# Altium schematic sheet Sheet1.SchDoc
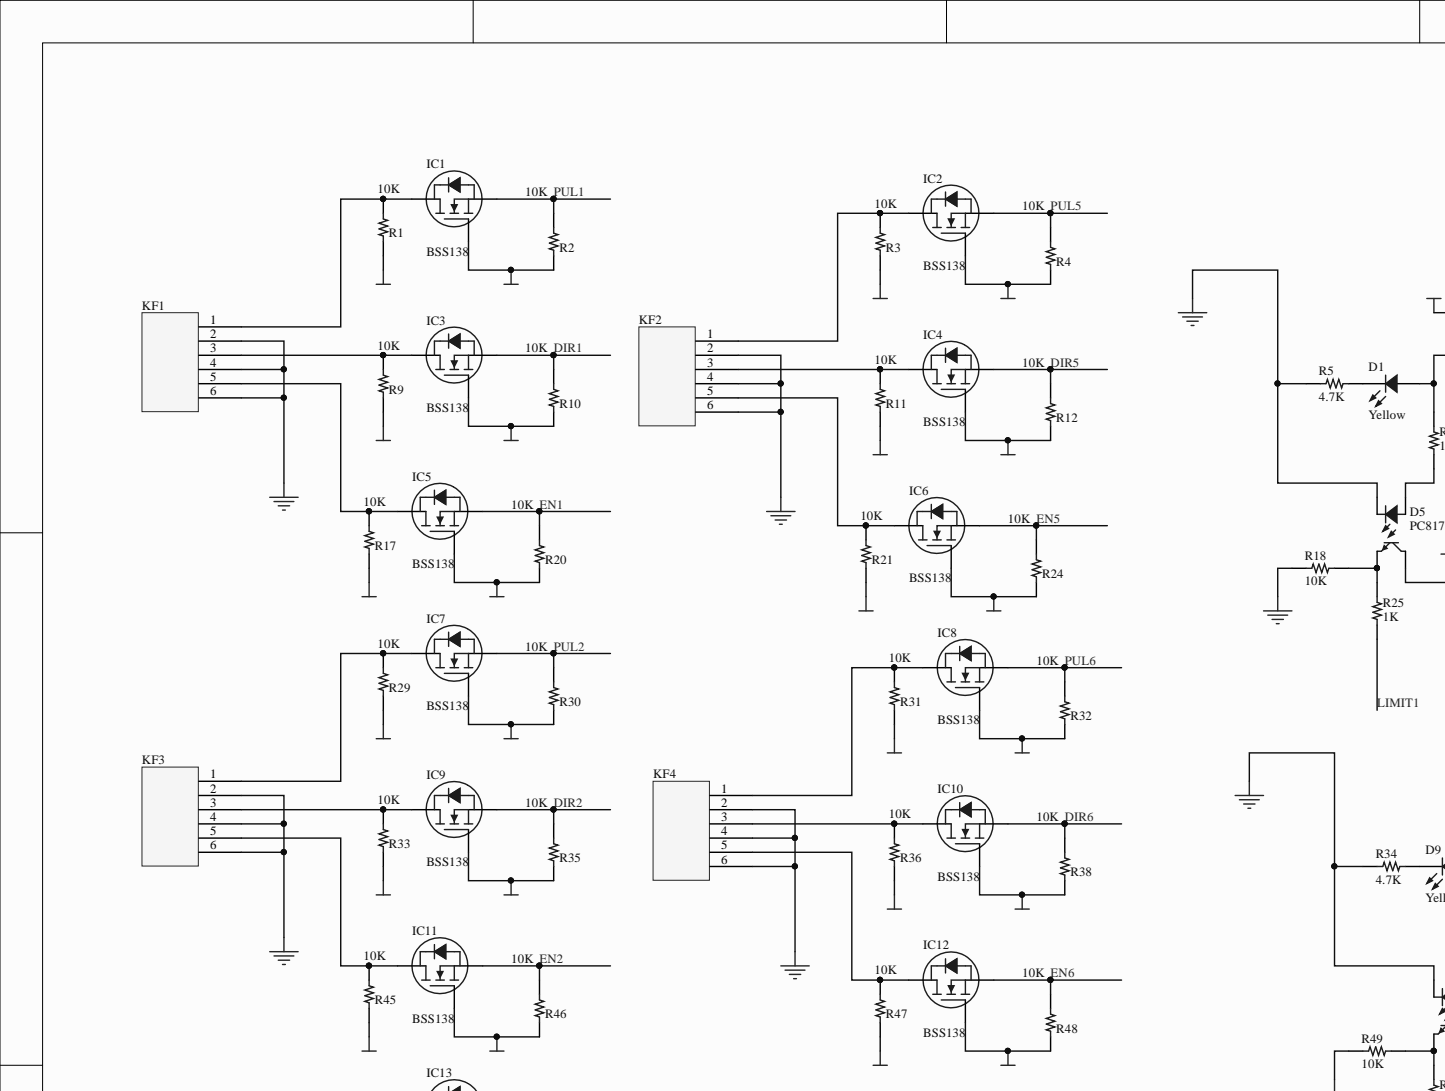
[diagram p0: Sheet1.SchDoc, top left]
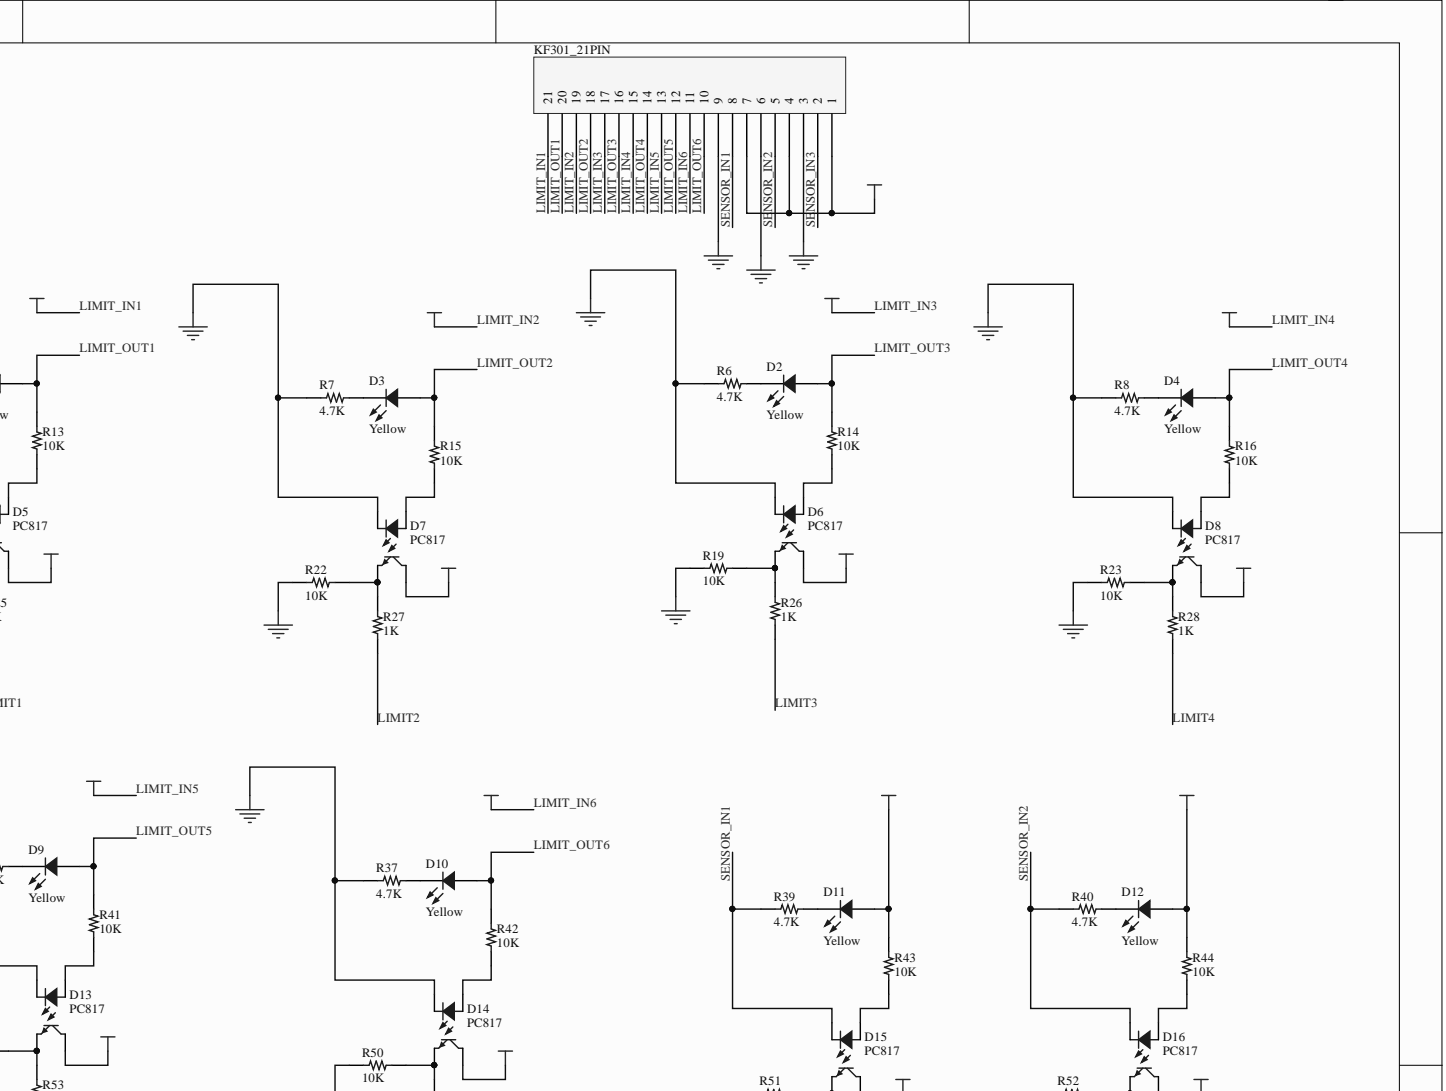
[diagram p1: Sheet1.SchDoc, top right]
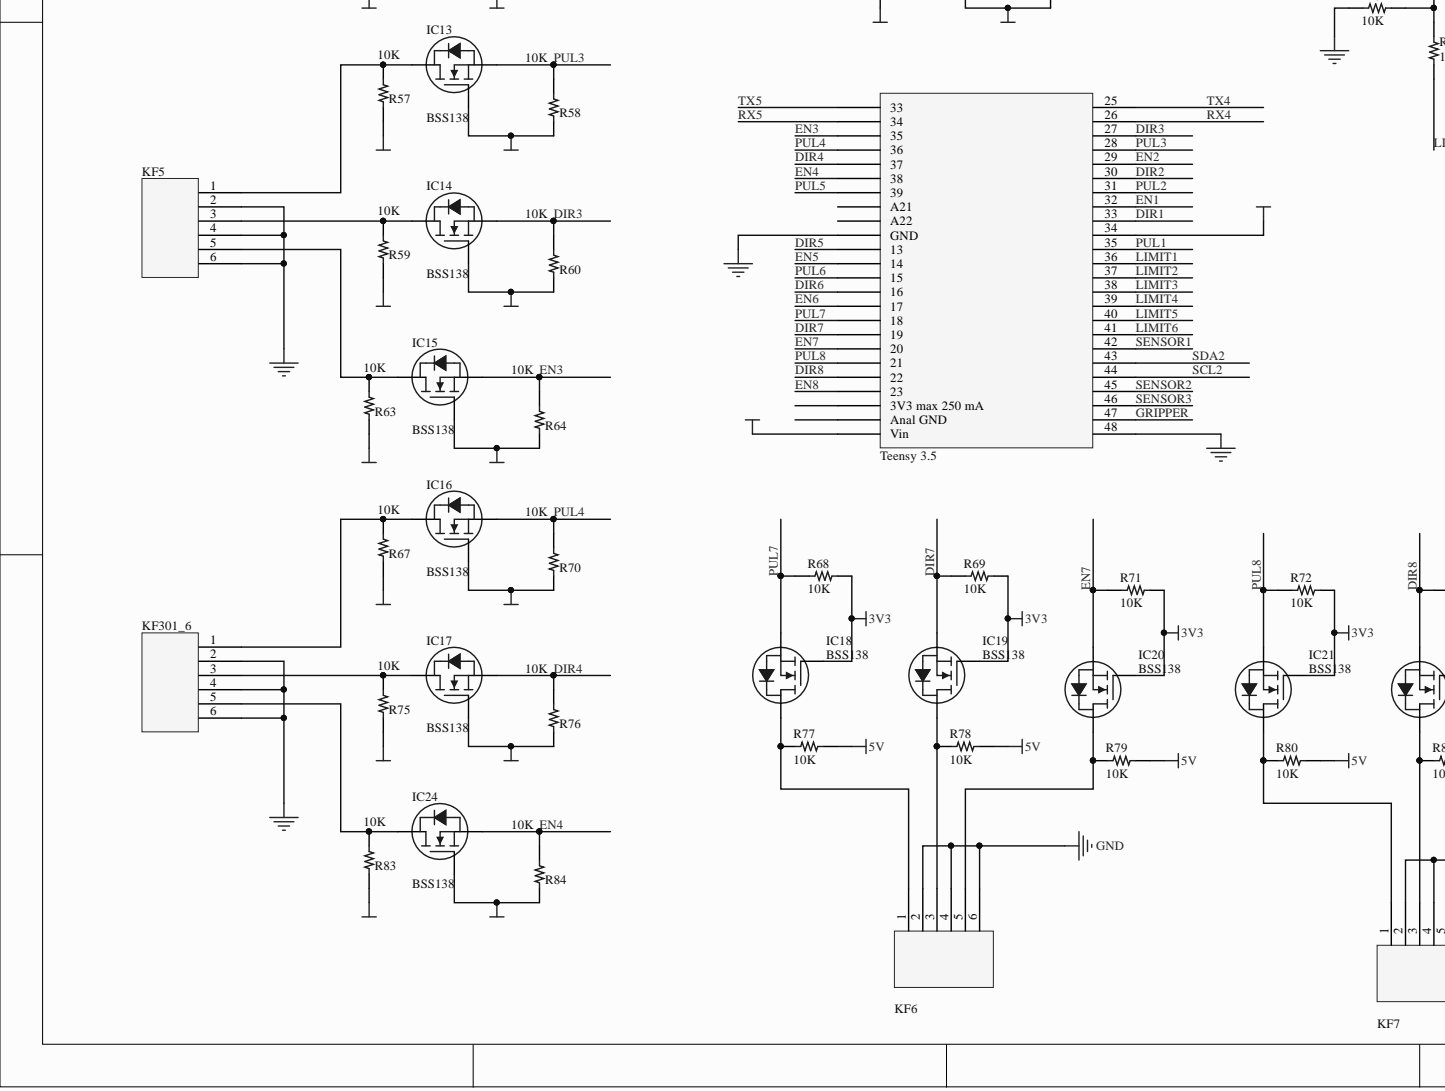
[diagram p2: Sheet1.SchDoc, bottom left]
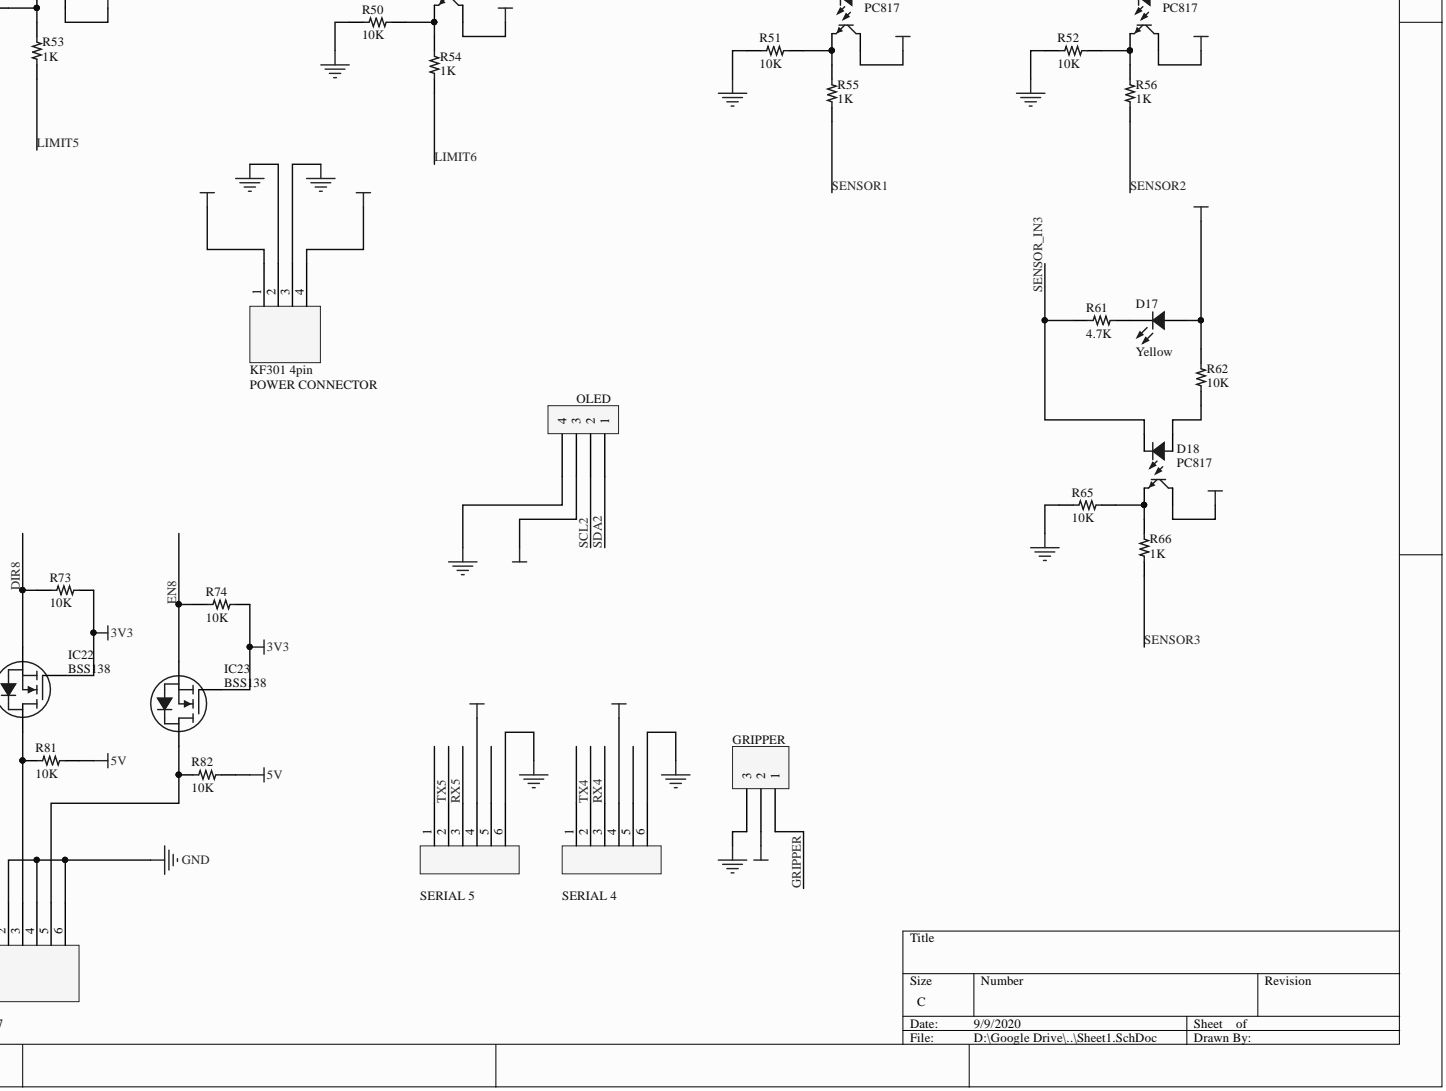
[diagram p3: Sheet1.SchDoc, bottom right]

## Parts
*  OLED  [4pin]
*  SERIAL 5  [header_6pin]
*?  SERIAL 4  [header_6pin]
D1  Yellow  [LED 0603_1608 YELLOW]
D2  Yellow  [LED 0603_1608 YELLOW]
D3  Yellow  [LED 0603_1608 YELLOW]
D4  Yellow  [LED 0603_1608 YELLOW]
D5  PC817  [LITEON LTV-817]
D6  PC817  [LITEON LTV-817]
D7  PC817  [LITEON LTV-817]
D8  PC817  [LITEON LTV-817]
D9  Yellow  [LED 0603_1608 YELLOW]
D10  Yellow  [LED 0603_1608 YELLOW]
D11  Yellow  [LED 0603_1608 YELLOW]
D12  Yellow  [LED 0603_1608 YELLOW]
D13  PC817  [LITEON LTV-817]
D14  PC817  [LITEON LTV-817]
D15  PC817  [LITEON LTV-817]
D16  PC817  [LITEON LTV-817]
D17  Yellow  [LED 0603_1608 YELLOW]
D18  PC817  [LITEON LTV-817]
GRIPPER  Component_3  [KF301_3PIN]  (value not drawn)
IC1  BSS138  [DIODES SOT-23-3]
IC2  BSS138  [DIODES SOT-23-3]
IC3  BSS138  [DIODES SOT-23-3]
IC4  BSS138  [DIODES SOT-23-3]
IC5  BSS138  [DIODES SOT-23-3]
IC6  BSS138  [DIODES SOT-23-3]
IC7  BSS138  [DIODES SOT-23-3]
IC8  BSS138  [DIODES SOT-23-3]
IC9  BSS138  [DIODES SOT-23-3]
IC10  BSS138  [DIODES SOT-23-3]
IC11  BSS138  [DIODES SOT-23-3]
IC12  BSS138  [DIODES SOT-23-3]
IC13  BSS138  [DIODES SOT-23-3]
IC14  BSS138  [DIODES SOT-23-3]
IC15  BSS138  [DIODES SOT-23-3]
IC16  BSS138  [DIODES SOT-23-3]
IC17  BSS138  [DIODES SOT-23-3]
IC18  BSS138  [DIODES SOT-23-3]
IC19  BSS138  [DIODES SOT-23-3]
IC20  BSS138  [DIODES SOT-23-3]
IC21  BSS138  [DIODES SOT-23-3]
IC22  BSS138  [DIODES SOT-23-3]
IC23  BSS138  [DIODES SOT-23-3]
IC24  BSS138  [DIODES SOT-23-3]
KF1  component_2  [KF301_6pin_pcb]  (value not drawn)
KF2  component_2  [KF301_6pin_pcb]  (value not drawn)
KF3  component_2  [KF301_6pin_pcb]  (value not drawn)
KF4  component_2  [KF301_6pin_pcb]  (value not drawn)
KF5  component_2  [KF301_6pin_pcb]  (value not drawn)
KF6  component_2  [KF301_6pin_pcb]  (value not drawn)
KF7  component_2  [KF301_6pin_pcb]  (value not drawn)
KF301_4pin  POWER CONNECTOR  [KF301_4pin]
KF301_6  component_2  [KF301_6pin_pcb]  (value not drawn)
KF301_21PIN  Component_1  [PCBComponent_1]  (value not drawn)
R1  10K  [RES 0603_1608]
R2  10K  [RES 0603_1608]
R3  10K  [RES 0603_1608]
R4  10K  [RES 0603_1608]
R5  4.7K  [RES 0603_1608]
R6  4.7K  [RES 0603_1608]
R7  4.7K  [RES 0603_1608]
R8  4.7K  [RES 0603_1608]
R9  10K  [RES 0603_1608]
R10  10K  [RES 0603_1608]
R11  10K  [RES 0603_1608]
R12  10K  [RES 0603_1608]
R13  10K  [RES 0603_1608]
R14  10K  [RES 0603_1608]
R15  10K  [RES 0603_1608]
R16  10K  [RES 0603_1608]
R17  10K  [RES 0603_1608]
R18  10K  [RES 0603_1608]
R19  10K  [RES 0603_1608]
R20  10K  [RES 0603_1608]
R21  10K  [RES 0603_1608]
R22  10K  [RES 0603_1608]
R23  10K  [RES 0603_1608]
R24  10K  [RES 0603_1608]
R25  1K  [RES 0603_1608]
R26  1K  [RES 0603_1608]
R27  1K  [RES 0603_1608]
R28  1K  [RES 0603_1608]
R29  10K  [RES 0603_1608]
R30  10K  [RES 0603_1608]
R31  10K  [RES 0603_1608]
R32  10K  [RES 0603_1608]
R33  10K  [RES 0603_1608]
R34  4.7K  [RES 0603_1608]
R35  10K  [RES 0603_1608]
R36  10K  [RES 0603_1608]
R37  4.7K  [RES 0603_1608]
R38  10K  [RES 0603_1608]
R39  4.7K  [RES 0603_1608]
R40  4.7K  [RES 0603_1608]
R41  10K  [RES 0603_1608]
R42  10K  [RES 0603_1608]
R43  10K  [RES 0603_1608]
R44  10K  [RES 0603_1608]
R45  10K  [RES 0603_1608]
R46  10K  [RES 0603_1608]
R47  10K  [RES 0603_1608]
R48  10K  [RES 0603_1608]
R49  10K  [RES 0603_1608]
R50  10K  [RES 0603_1608]
R51  10K  [RES 0603_1608]
R52  10K  [RES 0603_1608]
R53  1K  [RES 0603_1608]
R54  1K  [RES 0603_1608]
R55  1K  [RES 0603_1608]
R56  1K  [RES 0603_1608]
R57  10K  [RES 0603_1608]
R58  10K  [RES 0603_1608]
R59  10K  [RES 0603_1608]
R60  10K  [RES 0603_1608]
R61  4.7K  [RES 0603_1608]
R62  10K  [RES 0603_1608]
R63  10K  [RES 0603_1608]
R64  10K  [RES 0603_1608]
R65  10K  [RES 0603_1608]
R66  1K  [RES 0603_1608]
R67  10K  [RES 0603_1608]
R68  10K  [RES 0603_1608]
R69  10K  [RES 0603_1608]
R70  10K  [RES 0603_1608]
R71  10K  [RES 0603_1608]
R72  10K  [RES 0603_1608]
R73  10K  [RES 0603_1608]
R74  10K  [RES 0603_1608]
R75  10K  [RES 0603_1608]
R76  10K  [RES 0603_1608]
R77  10K  [RES 0603_1608]
R78  10K  [RES 0603_1608]
R79  10K  [RES 0603_1608]
R80  10K  [RES 0603_1608]
R81  10K  [RES 0603_1608]
R82  10K  [RES 0603_1608]
R83  10K  [RES 0603_1608]
R84  10K  [RES 0603_1608]
Teensy_3.5  Teensy 3.5 board  [Teensy3_5]  (value not drawn)

## Nets
3V3: *.3 *.4 *?.4 D5.4 D6.4 D7.4 D8.4 D13.4 D14.4 D15.4 D16.4 D18.4 IC1.1 IC2.1 IC3.1 IC4.1 IC5.1 IC6.1 IC7.1 IC8.1 IC9.1 IC10.1 IC11.1 IC12.1 IC13.1 IC14.1 IC15.1 IC16.1 IC17.1 IC18.1 IC19.1 IC20.1 IC21.1 IC22.1 IC23.1 IC24.1 R2.1 R4.1 R10.1 R12.1 R20.1 R24.1 R30.1 R32.1 R35.1 R38.1 R46.1 R48.1 R58.1 R60.1 R64.1 R68.1 R69.1 R70.1 R71.1 R72.1 R73.1 R74.1 R76.1 R84.1 Teensy_3.5.34
5V: GRIPPER.2 KF301_4pin.1 R1.1 R3.1 R9.1 R11.1 R17.1 R21.1 R29.1 R31.1 R33.1 R36.1 R45.1 R47.1 R57.1 R59.1 R63.1 R67.1 R75.1 R77.1 R78.1 R79.1 R80.1 R81.1 R82.1 R83.1 Teensy_3.5.24(Vin)
GND: *.4 *.6 *?.6 GRIPPER.3 KF1.2 KF1.4 KF1.6 KF2.2 KF2.4 KF2.6 KF3.2 KF3.4 KF3.6 KF4.2 KF4.4 KF4.6 KF5.2 KF5.4 KF5.6 KF6.2 KF6.4 KF6.6 KF7.2 KF7.4 KF7.6 KF301_4pin.2 KF301_6.2 KF301_6.4 KF301_6.6 R18.1 R19.1 R22.1 R23.1 R49.1 R50.1 R51.1 R52.1 R65.1 Teensy_3.5.10(GND) Teensy_3.5.48
HV GND: D5.2 D6.2 D7.2 D8.2 D13.2 D14.2 KF301_4pin.3 KF301_21PIN.3 KF301_21PIN.6 KF301_21PIN.9 R5.2 R6.2 R7.2 R8.2 R34.2 R37.2
DIR1: IC3.2 R10.2 Teensy_3.5.33
DIR2: IC9.2 R35.2 Teensy_3.5.30
DIR3: IC14.2 R60.2 Teensy_3.5.27
DIR4: IC17.2 R76.2 Teensy_3.5.5
DIR5: IC4.2 R12.2 Teensy_3.5.11
DIR6: IC10.2 R38.2 Teensy_3.5.14
DIR7: IC19.2 R69.2 Teensy_3.5.17
DIR8: IC22.2 R73.2 Teensy_3.5.20
EN1: IC5.2 R20.2 Teensy_3.5.32
EN2: IC11.2 R46.2 Teensy_3.5.29
EN3: IC15.2 R64.2 Teensy_3.5.3
EN4: IC24.2 R84.2 Teensy_3.5.6
EN5: IC6.2 R24.2 Teensy_3.5.12
EN6: IC12.2 R48.2 Teensy_3.5.15
EN7: IC20.2 R71.2 Teensy_3.5.18
EN8: IC23.2 R74.2 Teensy_3.5.21
GRIPPER: GRIPPER.1 Teensy_3.5.47
LIMIT1: R25.1 Teensy_3.5.36
LIMIT2: R27.1 Teensy_3.5.37
LIMIT3: R26.1 Teensy_3.5.38
LIMIT4: R28.1 Teensy_3.5.39
LIMIT5: R53.1 Teensy_3.5.40
LIMIT6: R54.1 Teensy_3.5.41
LIMIT_IN1: D11.1 D12.1 D17.1 KF301_4pin.4 KF301_21PIN.1 KF301_21PIN.4 KF301_21PIN.7 KF301_21PIN.11 KF301_21PIN.13 KF301_21PIN.15 KF301_21PIN.17 KF301_21PIN.19 KF301_21PIN.21 R43.2 R44.2 R62.2
LIMIT_OUT1: D1.1 KF301_21PIN.20 R13.2
LIMIT_OUT2: D3.1 KF301_21PIN.18 R15.2
LIMIT_OUT3: D2.1 KF301_21PIN.16 R14.2
LIMIT_OUT4: D4.1 KF301_21PIN.14 R16.2
LIMIT_OUT5: D9.1 KF301_21PIN.12 R41.2
LIMIT_OUT6: D10.1 KF301_21PIN.10 R42.2
PUL1: IC1.2 R2.2 Teensy_3.5.35
PUL2: IC7.2 R30.2 Teensy_3.5.31
PUL3: IC13.2 R58.2 Teensy_3.5.28
PUL4: IC16.2 R70.2 Teensy_3.5.4
PUL5: IC2.2 R4.2 Teensy_3.5.7
PUL6: IC8.2 R32.2 Teensy_3.5.13
PUL7: IC18.2 R68.2 Teensy_3.5.16
PUL8: IC21.2 R72.2 Teensy_3.5.19
RX4: *?.3 Teensy_3.5.26
RX5: *.3 Teensy_3.5.2
SCL2: *.2 Teensy_3.5.44
SDA2: *.1 Teensy_3.5.43
SENSOR1: R55.1 Teensy_3.5.42
SENSOR2: R56.1 Teensy_3.5.45
SENSOR3: R66.1 Teensy_3.5.46
SENSOR_IN1: D15.2 KF301_21PIN.8 R39.2
SENSOR_IN2: D16.2 KF301_21PIN.5 R40.2
SENSOR_IN3: D18.2 KF301_21PIN.2 R61.2
TX4: *?.2 Teensy_3.5.25
TX5: *.2 Teensy_3.5.1
NetD1_2: D1.2 R5.1
NetD2_2: D2.2 R6.1
NetD3_2: D3.2 R7.1
NetD4_2: D4.2 R8.1
NetD5_1: D5.1 R13.1
NetD5_3: D5.3 R18.2 R25.2
NetD6_1: D6.1 R14.1
NetD6_3: D6.3 R19.2 R26.2
NetD7_1: D7.1 R15.1
NetD7_3: D7.3 R22.2 R27.2
NetD8_1: D8.1 R16.1
NetD8_3: D8.3 R23.2 R28.2
NetD9_2: D9.2 R34.1
NetD10_2: D10.2 R37.1
NetD11_2: D11.2 R39.1
NetD12_2: D12.2 R40.1
NetD13_1: D13.1 R41.1
NetD13_3: D13.3 R49.2 R53.2
NetD14_1: D14.1 R42.1
NetD14_3: D14.3 R50.2 R54.2
NetD15_1: D15.1 R43.1
NetD15_3: D15.3 R51.2 R55.2
NetD16_1: D16.1 R44.1
NetD16_3: D16.3 R52.2 R56.2
NetD17_2: D17.2 R61.1
NetD18_1: D18.1 R62.1
NetD18_3: D18.3 R65.2 R66.2
NetIC1_3: IC1.3 KF1.1 R1.2
NetIC2_3: IC2.3 KF2.1 R3.2
NetIC3_3: IC3.3 KF1.3 R9.2
NetIC4_3: IC4.3 KF2.3 R11.2
NetIC5_3: IC5.3 KF1.5 R17.2
NetIC6_3: IC6.3 KF2.5 R21.2
NetIC7_3: IC7.3 KF3.1 R29.2
NetIC8_3: IC8.3 KF4.1 R31.2
NetIC9_3: IC9.3 KF3.3 R33.2
NetIC10_3: IC10.3 KF4.3 R36.2
NetIC11_3: IC11.3 KF3.5 R45.2
NetIC12_3: IC12.3 KF4.5 R47.2
NetIC13_3: IC13.3 KF5.1 R57.2
NetIC14_3: IC14.3 KF5.3 R59.2
NetIC15_3: IC15.3 KF5.5 R63.2
NetIC16_3: IC16.3 KF301_6.1 R67.2
NetIC17_3: IC17.3 KF301_6.3 R75.2
NetIC18_3: IC18.3 KF6.1 R77.2
NetIC19_3: IC19.3 KF6.3 R78.2
NetIC20_3: IC20.3 KF6.5 R79.2
NetIC21_3: IC21.3 KF7.1 R80.2
NetIC22_3: IC22.3 KF7.3 R81.2
NetIC23_3: IC23.3 KF7.5 R82.2
NetIC24_3: IC24.3 KF301_6.5 R83.2
N00035:

Not connected: * 5; *? 1 5; Teensy_3.5 8(A21) 9(A22) 22(3V3_max_250_mA) 23(Anal_GND)

## Library data of the parts
* = 4pin: Component Kind: Standard; Library Name: 4pin.SchLib
* *? = pin_header6pin: Component Kind: Standard; Library Name: 6pin.SchLib
D1-D4 D9-D12 D17 = CSL0901WT: HIGH BRIGHTNESS LENS TYPE LED; C; Manufacturer: Rohm Semiconductor; Configuration: 0603; Current - Test: 20mA; Database Table Name: LED - Indication; Lens Color: Colorless; Lens Size: 1.14mm Dia; Lens Style: Round with Domed Top; Lens Transparency: Clear; Millicandela Rating: 280mcd; Voltage - Forward (Vf) (Typ): 2.1V; Wavelength - Dominant: 587nm; Color: Yellow; Component Kind: Standard; ComponentLink1Description: Datasheet; ComponentLink1URL: https://www.rohm.com/datasheet/CSL0901WT/csl0901-e; ComponentLink2Description: DigiKey Link; ComponentLink2URL: http://digikey.com/product-detail/en/rohm-semiconductor/CSL0901WT/CSL0901WTCT-ND/10222169; Device Package: 0603 (1608 Metric); Height (Max): 1.34mm; LastUpdated: 9/2/2020 1:21:18 AM; Library Name: Celestial Altium Library - altium_library.DbLib; Lifecycle Status: Active; Minimum Order: 1; Mounting Type: Surface Mount; Package / Case: 0603 (1608 Metric); Packaging: TapeAndReel; PartId: 88; Part Number: CSL0901WT; Part Status: Active; Price: 0.12; Series: CSL090x; Size / Dimension: 1.60mm L x 0.80mm W; Supplier 1: DigiKey; Supplier Device Package: 0603; Supplier Part Number 1: CSL0901WTCT-ND; Value: Yellow
D5-D8 D13-D16 D18 = LTV-817S-TA1: OPTOISOLATR 5KV TRANSISTOR 4-SMD; Manufacturer: Lite-On Inc.; Current - DC Forward (If) (Max): 50mA; Current Transfer Ratio (Max): 600% @ 5mA; Current Transfer Ratio (Min): 50% @ 5mA; Database Table Name: Optoisolators - Transistor Photovoltaic; Input Type: DC; Number of Channels: 1; Rise / Fall Time (Typ): 4µs, 3µs; Vce Saturation (Max): 200mV; Voltage - Forward (Vf) (Typ): 1.2V; Voltage - Isolation: 5000Vrms; Voltage - Output (Max): 35V; Component Kind: Standard; ComponentLink1Description: Datasheet; ComponentLink1URL: http://optoelectronics.liteon.com/upload/download/DS-70-96-0016/LTV-8X7%20series%20201610%20.pdf; ComponentLink2Description: DigiKey Link; ComponentLink2URL: http://digikey.com/product-detail/en/lite-on-inc/LTV-817S-TA1/160-1893-1-ND/3306757; Current - Output / Channel: 50mA; Device Package: 4-SMD, Gull Wing; LastUpdated: 1/12/2020 9:58:48 PM; Library Name: Celestial Altium Library - altium_library.DbLib; Lifecycle Status: Active; Minimum Order: 1; Mounting Type: Surface Mount; Operating Temperature: -30°C ~ 110°C; Output Type: Transistor; Package / Case: 4-SMD, Gull Wing; Packaging: TapeAndReel; Part Number: LTV-817S-TA1; Part Status: Active; Price: 0.12; Series: -; Supplier 1: DigiKey; Supplier Device Package: 4-SMD; Supplier Part Number 1: 160-1893-1-ND
IC1-IC24 = DMN2020LSN-7: MOSFET N-CH 20V 6.9A SC59; Manufacturer: Diodes Incorporated; Current - Continuous Drain (Id) @ 25°C: 6.9A (Ta); Database Table Name: MOSFET - Single - N-Ch; Drive Voltage (Max Rds On,  Min Rds On): 2.5V, 4.5V; FET Type: N-Channel; Gate Charge (Qg) (Max) @ Vgs: 11.6nC @ 4.5V; Input Capacitance (Ciss) (Max) @ Vds: 1149pF @ 10V; Power Dissipation (Max): 610mW (Ta); Rds On (Max) @ Id, Vgs: 20mOhm @ 9.4A, 4.5V; Vgs (Max): ±12V; Vgs(th) (Max) @ Id: 1.5V @ 250µA; Component Kind: Standard; ComponentLink1Description: Datasheet; ComponentLink1URL: https://www.diodes.com/assets/Datasheets/ds31946.pdf; ComponentLink2Description: DigiKey Link; ComponentLink2URL: http://digikey.com/product-detail/en/diodes-incorporated/DMN2020LSN-7/DMN2020LSN-7DICT-ND/2182565; Drain to Source Voltage (Vdss): 20V; LastUpdated: 9/2/2020 1:25:01 AM; Library Name: Celestial Altium Library - altium_library.DbLib; Lifecycle Status: Active; Minimum Order: 1; Mounting Type: Surface Mount; Operating Temperature: -55°C ~ 150°C (TJ); Package / Case: TO-236-3, SC-59, SOT-23-3; Packaging: TapeAndReel; Part Number: DMN2020LSN-7; Part Status: Active; Price: 0.12; Series: -; Supplier 1: DigiKey; Supplier Device Package: SC-59-3; Supplier Part Number 1: DMN2020LSN-7DICT-ND; Technology: MOSFET (Metal Oxide)
KF301_4pin Teensy_3.5 = Component_1: Component Kind: Standard
  KF301_4pin: Library Name: KF301_4pin_sch.SchLib
  Teensy_3.5: Library Name: Teensy_sch.SchLib
R1-R84 = TLRZ1JTTD: RES 0 OHM JUMPER 0603; Manufacturer: KOA Speer Electronics, Inc.; Database Table Name: Resistors - Surface Mount - 0603; Component Kind: Standard; ComponentLink1Description: Datasheet; ComponentLink1URL: http://www.koaspeer.com/catimages/Products/TLRZ/TLRZ.pdf; ComponentLink2Description: DigiKey Link; ComponentLink2URL: http://digikey.com/product-detail/en/koa-speer-electronics-inc/TLRZ1JTTD/2019-TLRZ1JTTDCT-ND/9847537; Composition: Metal Element; Device Package: 0603 (1608 Metric); Features: Automotive AEC-Q200, Current Sense; Height - Seated (Max): 0.022" (0.55mm); LastUpdated: 9/2/2020 1:10:25 AM; Library Name: Celestial Altium Library - altium_library.DbLib; Lifecycle Status: Active; Minimum Order: 1; Number of Terminations: 2; Operating Temperature: -55°C ~ 170°C; Package / Case: 0603 (1608 Metric); Packaging: TapeAndReel; PartId: 4851; Part Number: TLRZ1JTTD; Part Status: Active; Price: 0.08; Ratings: AEC-Q200; Series: TLRZ; Size / Dimension: 0.063" L x 0.031" W (1.60mm x 0.80mm); Supplier 1: DigiKey; Supplier Device Package: 0603; Supplier Part Number 1: 2019-TLRZ1JTTDCT-ND; Tolerance: Jumper
GRIPPER = Component_3: Component Kind: Standard; Library Name: KF301_3PIN_SCH.SchLib
KF1-KF7 KF301_6 = component_2: Component Kind: Standard; Library Name: KF301_6pin_sch.SchLib
KF301_21PIN = Component_1: Component Kind: Standard; Library Name: KF301_21PIN_SCH.SchLib
